# Altium schematic sheet MAIN.SchDoc(MAIN)
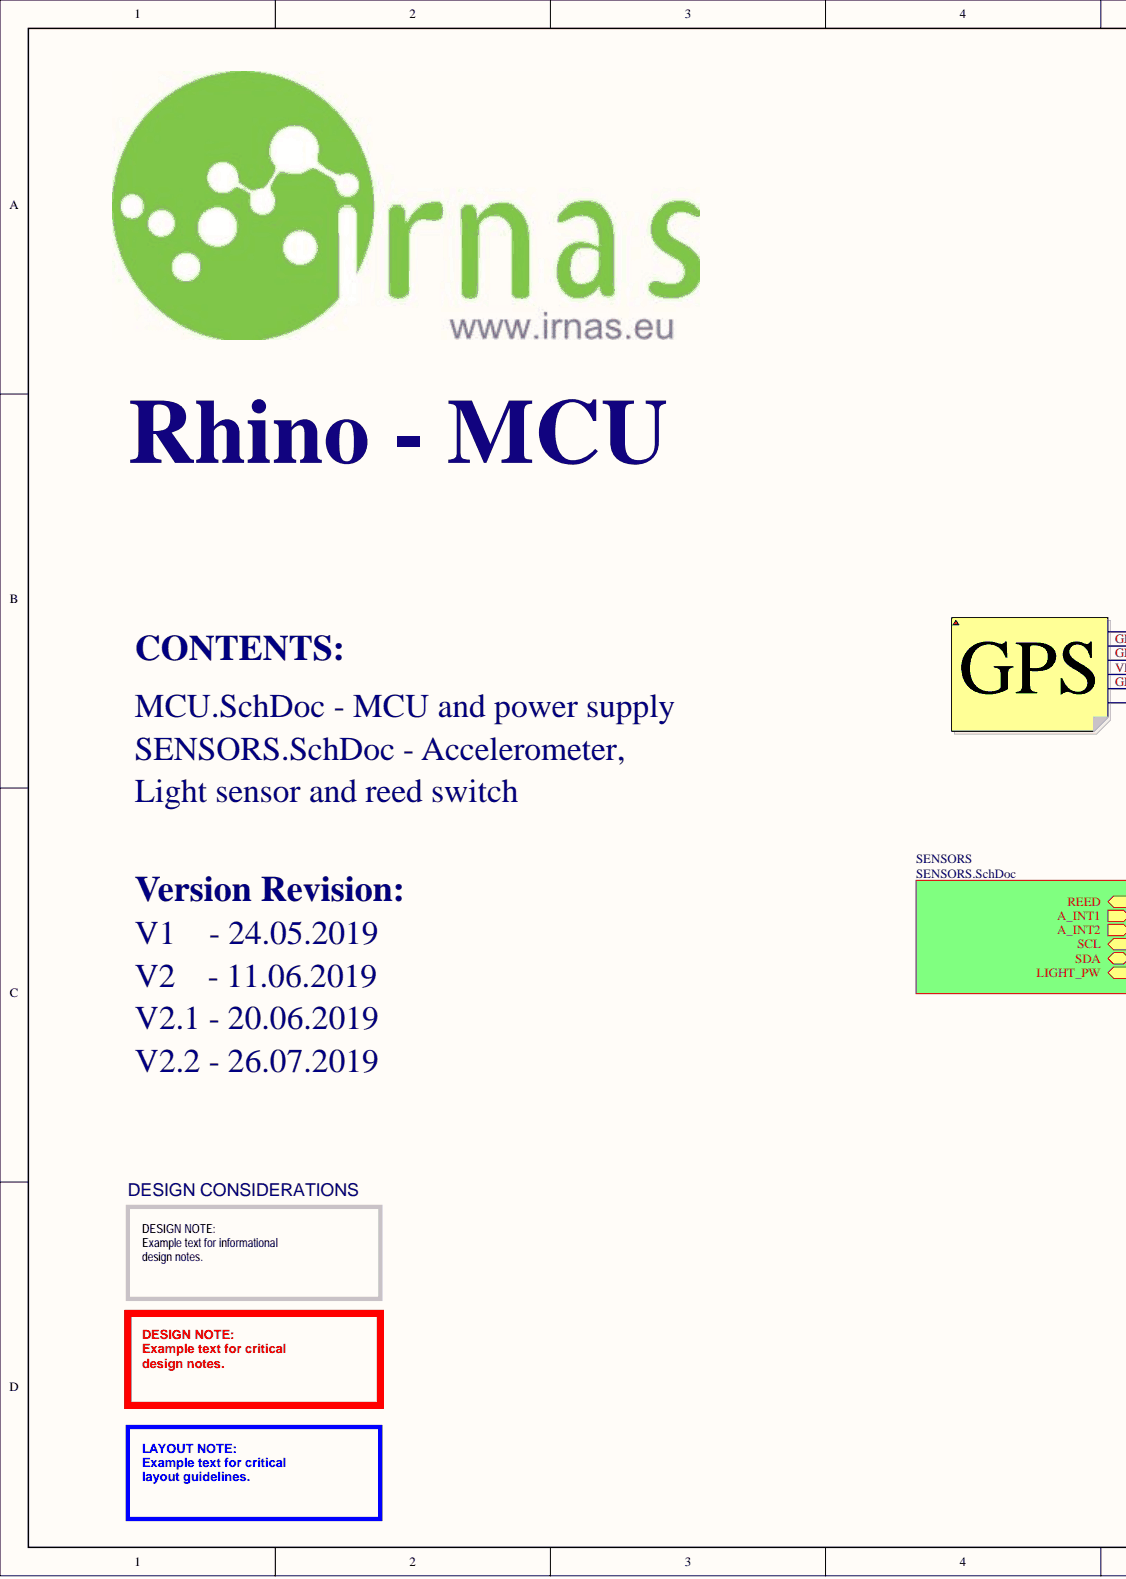
[diagram p0: MAIN.SchDoc, left]
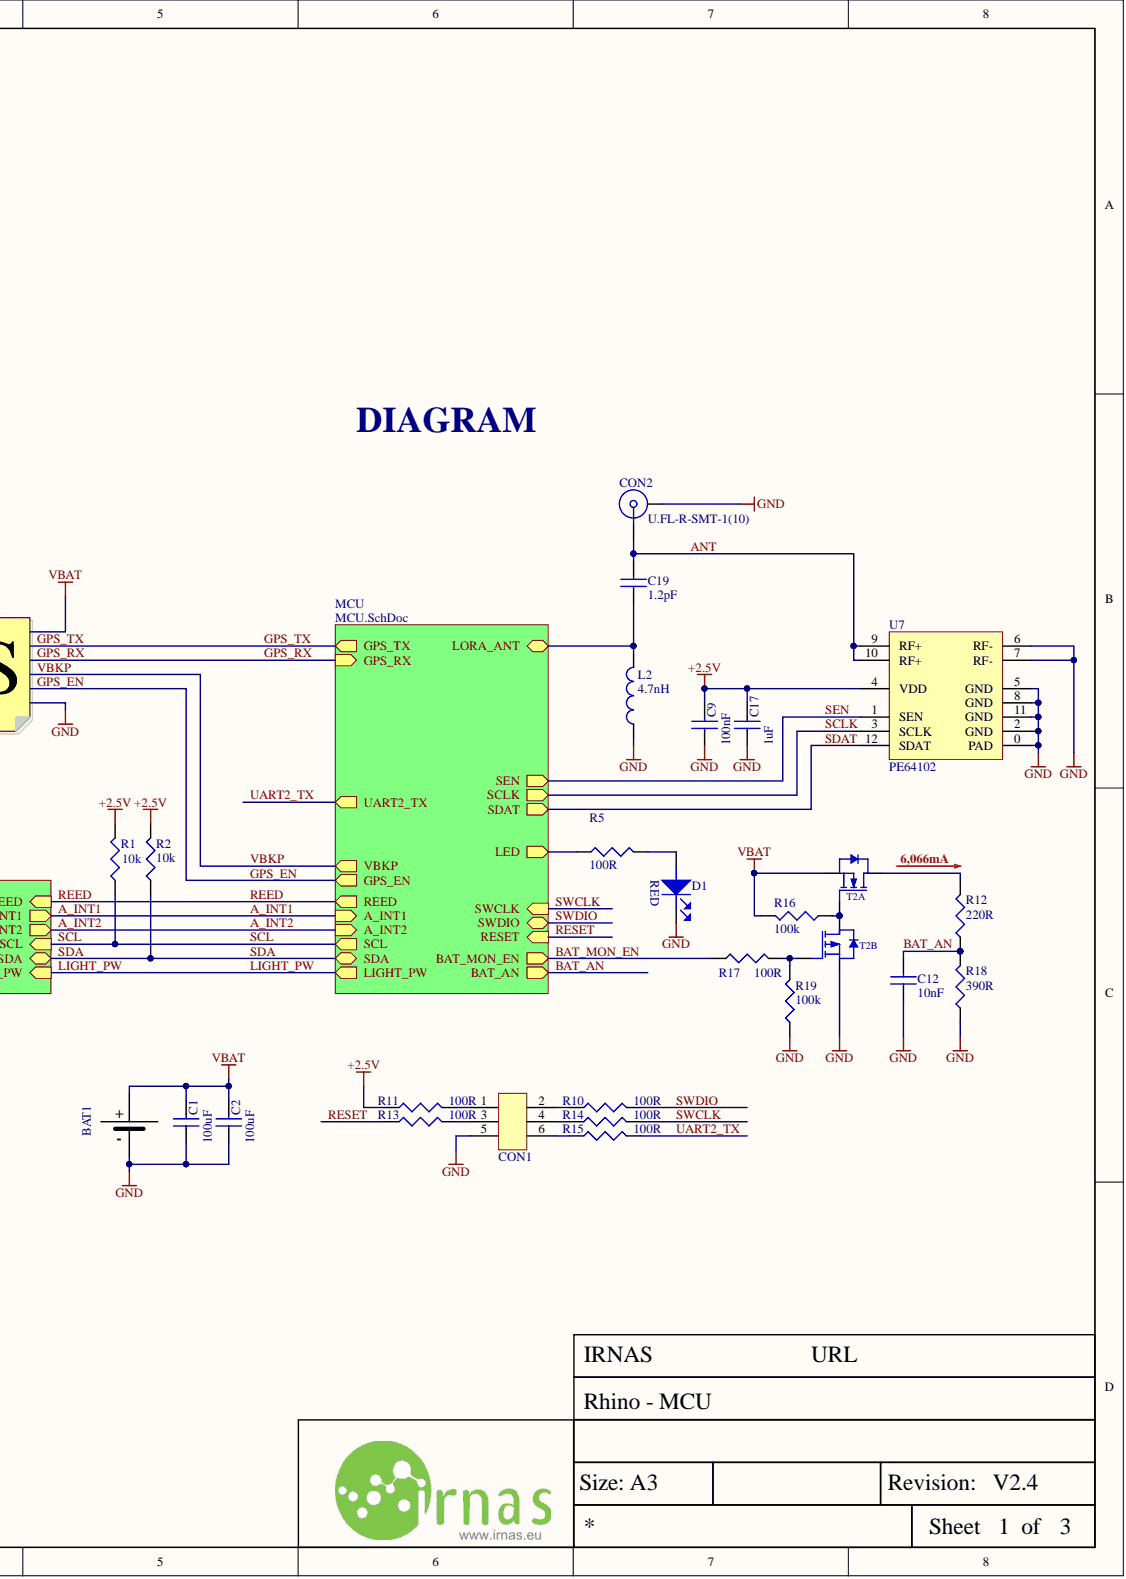
[diagram p1: MAIN.SchDoc, right]

## Parts
BAT1  SAFT LS 14250PFR  [SAFT LS 14250PFR]  (value not drawn)
C1  100uF  [CAP0805]
C2  100uF  [CAP0805]
C9  100nF  [CAP0402]
C12  10nF  [CAP0402]
C17  1uF  [CAP0402]
C19  1.2pF  [CAP0402]
CON1  Tag connect  [TC2030-IDC-NL]  (value not drawn)  (no BOM)
CON2  U.FL-R-SMT-1(10)  [ufl connector smd]
D1  LED RED  [LED0603]  (value not drawn)
L2  4.7nH  [IND0402]
R1  10k  [RES0402]
R2  10k  [RES0402]
R5  100R  [RES0402]
R10  100R  [RES0402]
R11  100R  [RES0402]
R12  220R  [RES0402]
R13  100R  [RES0402]
R14  100R  [RES0402]
R15  100R  [RES0402]
R16  100k  [RES0402]
R17  100R  [RES0402]
R18  390R  [RES0402]
R19  100k  [RES0402]
T2  VT6M1T2CR  [VMT6]  (value not drawn)  (parts A,B)
U7  PE64102  [QFN-12]

## Nets
+2.5V: C9.2 C17.2 R1.2 R2.2 R11.1 U7.4(VDD)
GND: BAT1.1 C1.1 C2.1 C9.1 C12.1 C17.1 CON1.5 CON2.2 D1.1 L2.1 R18.1 R19.1 T2.4 U7.0(PAD) U7.2(GND) U7.5(GND) U7.6(RF-) U7.7(RF-) U7.8(GND) U7.11(GND)
VBAT: BAT1.2 C1.2 C2.2 R16.2 T2.1
ANT: C19.2 CON2.1 U7.9(RF+) U7.10(RF+)
BAT_AN: C12.2 R12.1 R18.2
BAT_MON_EN: R17.1
RESET: R13.1
SCL: R1.1
SCLK: U7.3(SCLK)
SDA: R2.1
SDAT: U7.12(SDAT)
SEN: U7.1(SEN)
SWCLK: R14.2
SWDIO: R10.2
UART2_TX: R15.2
NetC19_1: C19.1 L2.2
NetCON1_1: CON1.1 R11.2
NetCON1_2: CON1.2 R10.1
NetCON1_3: CON1.3 R13.2
NetCON1_4: CON1.4 R14.1
NetCON1_6: CON1.6 R15.1
NetD1_2: D1.2 R5.2
NetR5_1: R5.1
NetR12_2: R12.2 T2.6
NetR16_1: R16.1 T2.2 T2.3
NetR17_2: R17.2 R19.2 T2.5
A_INT1:
A_INT2:
GPS_EN:
GPS_RX:
GPS_TX:
LIGHT_PW:
REED:
VBKP:

## Library data of the parts
BAT1 = BAT - SAFT LS 14250PFR: Battery: lithium; 3.6V; 1/2AA; 3pin,positive pole: 1pin; 1200mAh; Supplier Part Number 1: SAFT-LS14250PFR; Supplier 1: TME; Library Name: Mechanical.SchLib; Component Kind: Standard
C1 C2 = C - 100uF/0805: Multilayer Ceramic Capacitors MLCC - SMD/SMT 0805 100uF 4volts *Derate Voltage/Temp; Manufacturer Part Number: GRM21BR60G107ME15L; Manufacturer: Murata; IRNAS Part No.: /; Voltage Rating (DC): 4V; Tolerance: 20%; Dissipation Factor: 0.1%; Dielectric: X5R; Width: 0.049inch; Weight: 0.000335oz; Voltage Rating: 4V; Voltage: 4V; Thickness: 0.057inch; Termination: SMD/SMT; Supplier Part Number 1: 2611937; Supplier 1: Farnell; Stock 1: 529; REACH SVHC: No SVHC; Pricing 1: 5=0.775, 50=0.463, 250=0.405, 500=0.289, 1500=0.243, 3000=0.229, 15000=0.21 (GBP); Packaging: Tape and Reel; Package Quantity: 3000; Mount: Surface Mount; Min Operating Temperature: -55°C; Max Operating Temperature: 85°C; Library Name: Passive.SchLib; Length: 2mm; Lead Free: Lead Free; Height: 1.25mm; Dielectric Material: Ceramic; Depth: 1.25mm; ComponentLink4URL: http://www.mouser.com/ds/2/281/c02e-2905.pdf; ComponentLink4Description: http://www.mouser.com/ds/2/281/c02e-2905.pdf; ComponentLink3URL: http://psearch.en.murata.com/capacitor/product/GRM21BR60G107ME15%23.pdf; ComponentLink3Description: http://psearch.en.murata.com/capacitor/product/GRM21BR60G107ME15%23.pdf; ComponentLink2URL: http://search.murata.co.jp/Ceramy/image/img/A01X/partnumbering_e_01.pdf; ComponentLink2Description: http://search.murata.co.jp/Ceramy/image/img/A01X/partnumbering_e_01.pdf; ComponentLink1URL: http://www.farnell.com/datasheets/2079181.pdf; ComponentLink1Description: http://www.farnell.com/datasheets/2079181.pdf; Component Kind: Standard; Case Code (Metric): 2012; Case Code (Imperial): 0805; Case/Package: 0805; Capacitance: 100uF
C9 = C - 100nF/0402: MULTICOMP         MC0402X104J160CT             SMD Multilayer Ceramic Capacitor, 0402 [1005 Metric], 0.1 F, 16 V,  5%, X5R, MC Series                          New; IRNAS Part No.: /; Voltage Rating (DC): 16V; Voltage Rating: 16V; Tolerance: 5%; Supplier Part Number 1: 2627419; Supplier 1: Farnell; Stock 1: 3230; REACH SVHC: No SVHC; Pricing 1: 10=0.0139, 100=0.0108, 500=0.0076, 2500=0.0051, 5000=0.0038 (EUR); Packaging: Tape and Reel; Min Operating Temperature: -55°C; Max Operating Temperature: 85°C; Manufacturer Part Number: MC0402X104J160CT; Manufacturer: Multicomp; Library Name: Passive.SchLib; Dielectric: X5R; ComponentLink2URL: http://www.farnell.com/datasheets/2117900.pdf; ComponentLink2Description: http://www.farnell.com/datasheets/2117900.pdf; ComponentLink1URL: http://www.farnell.com/datasheets/2117933.pdf; ComponentLink1Description: http://www.farnell.com/datasheets/2117933.pdf; Component Kind: Standard; Case Code (Metric): 1005; Case Code (Imperial): 0402; Capacitance: 100nF
C12 = C - 10nF/0402: CAP CER 10000PF 16V X7R 0402; Manufacturer Part Number: GRM155R71C103KA01D; Manufacturer: Murata; IRNAS Part No.: /; Tolerance: 10%; Width: 0.02inch; Voltage Rating (DC): 16V; Voltage Rating: 16V; Voltage: 16V; Thickness: 0.022inch; Termination: SMD/SMT; Supplier Part Number 1: 1828864; Supplier 1: Farnell; Stock 1: 16478; REACH SVHC: No SVHC; Radiation Hardening: No; Pricing 1: 10=0.0216, 100=0.0151, 500=0.0104, 2500=0.0069, 5000=0.0056 (EUR); Packaging: Tape and Reel; Package Quantity: 1; Number of Pins: 2; Mount: Surface Mount; Min Operating Temperature: -55°C; Max Operating Temperature: 125°C; Library Name: Passive.SchLib; Length: 1mm; Lead Free: Lead Free; Height: 0.5mm; Dielectric: X7R; Depth: 0.5mm; ComponentLink6URL: https://datasheet.ciiva.com/7937/608985-7937614.pdf; ComponentLink6Description: https://datasheet.ciiva.com/7937/608985-7937614.pdf; ComponentLink5URL: https://datasheet.ciiva.com/22698/608985-22698415.pdf; ComponentLink5Description: https://datasheet.ciiva.com/22698/608985-22698415.pdf; ComponentLink4URL: https://datasheet.ciiva.com/18184/getdatasheetpartid-178863-18184649.pdf; ComponentLink4Description: https://datasheet.ciiva.com/18184/getdatasheetpartid-178863-18184649.pdf; ComponentLink3URL: https://datasheet.ciiva.com/129/product-129776.pdf; ComponentLink3Description: https://datasheet.ciiva.com/129/product-129776.pdf; ComponentLink2URL: https://datasheet.ciiva.com/129/partnumbering-e-01-129775.pdf; ComponentLink2Description: https://datasheet.ciiva.com/129/partnumbering-e-01-129775.pdf; ComponentLink1URL: https://datasheet.ciiva.com/129/partnumbering-e-01-129774.pdf; ComponentLink1Description: https://datasheet.ciiva.com/129/partnumbering-e-01-129774.pdf; Component Kind: Standard; Case Code (Metric): 1005; Case Code (Imperial): 0402; Case/Package: 0402; Capacitance: 10nF
C17 = C - 1uF/0402: CAP CER 1UF 10V 20% X5R 0402; Manufacturer Part Number: GRM155R61A105ME15D; Manufacturer: Murata; IRNAS Part No.: /; Width: 0.02inch; Voltage Rating (DC): 10V; Voltage Rating: 10V; Voltage: 10V; Tolerance: 20%; Thickness: 0.022inch; Supplier Part Number 1: 2426953; Supplier 1: Farnell; Stock 1: 0; REACH SVHC: No SVHC; Radiation Hardening: No; Pricing 1: 10=0.0379, 100=0.0266, 500=0.0156, 2500=0.0098, 5000=0.0083 (EUR); Packaging: Tape and Reel; Package Quantity: 1; Mount: Surface Mount; Min Operating Temperature: -55°C; Max Operating Temperature: 85°C; Library Name: Passive.SchLib; Length: 1mm; Lead Free: Lead Free; Height: 0.5mm; Dielectric: X5R; Depth: 0.5mm; ComponentLink5URL: https://datasheet.ciiva.com/164/partnumbering-e-01-164667.pdf; ComponentLink5Description: https://datasheet.ciiva.com/164/partnumbering-e-01-164667.pdf; ComponentLink4URL: https://datasheet.ciiva.com/164/partnumbering-e-01-164666.pdf; ComponentLink4Description: https://datasheet.ciiva.com/164/partnumbering-e-01-164666.pdf; ComponentLink3URL: https://datasheet.ciiva.com/46418/1769893-46418047.pdf; ComponentLink3Description: https://datasheet.ciiva.com/46418/1769893-46418047.pdf; ComponentLink2URL: https://datasheet.ciiva.com/11616/product-11616508.pdf; ComponentLink2Description: https://datasheet.ciiva.com/11616/product-11616508.pdf; ComponentLink1URL: https://datasheet.ciiva.com/164/product-164665.pdf; ComponentLink1Description: https://datasheet.ciiva.com/164/product-164665.pdf; Component Kind: Standard; Case Code (Metric): 1005; Case Code (Imperial): 0402; Case/Package: 0402; Capacitance: 1uF
C19 = C - 4.7nF/0402: CAP CER 4700PF 50V X7R 0402; Manufacturer Part Number: C0402C472K5RAC-TU; Manufacturer: KEMET; IRNAS Part No.: /; Tolerance: 10%; Dielectric Material: Ceramic; Capacitance: 4.7nF; Width: 0.02inch; Voltage Rating (DC): 50V; Voltage Rating: 50V; Voltage: 50V; Thickness: 0.022inch; Termination: SMD/SMT; Supplier Part Number 1: 1414594; Supplier 1: Farnell; Stock 1: 98953; REACH SVHC: No SVHC; Radiation Hardening: No; Pricing 1: 10=0.0321, 100=0.0144, 500=0.0081, 2500=0.0057, 5000=0.0048 (EUR); Packaging: Tape and Reel; Package Quantity: 1; Number of Pins: 2; Mount: Surface Mount,PCB; Min Operating Temperature: -55°C; Max Operating Temperature: 125°C; Library Name: Passive.SchLib; Length: 1mm; Lead Pitch: 0.3mm; Height: 0.5mm; Dielectric: X7R; Depth: 0.5mm; ComponentLink6URL: https://datasheet.ciiva.com/18102/getdatasheetpartid-63228-18102058.pdf; ComponentLink6Description: https://datasheet.ciiva.com/18102/getdatasheetpartid-63228-18102058.pdf; ComponentLink5URL: https://datasheet.ciiva.com/14602/70095383-14602057.pdf; ComponentLink5Description: https://datasheet.ciiva.com/14602/70095383-14602057.pdf; ComponentLink4URL: https://datasheet.ciiva.com/6074/kem-c1002-x7r-smd-6074645.pdf; ComponentLink4Description: https://datasheet.ciiva.com/6074/kem-c1002-x7r-smd-6074645.pdf; ComponentLink3URL: https://datasheet.ciiva.com/14604/70095383-14604382.pdf; ComponentLink3Description: https://datasheet.ciiva.com/14604/70095383-14604382.pdf; ComponentLink2URL: https://datasheet.ciiva.com/7747/40889-7747550.pdf; ComponentLink2Description: https://datasheet.ciiva.com/7747/40889-7747550.pdf; ComponentLink1URL: https://datasheet.ciiva.com/7747/40889-7747549.pdf; ComponentLink1Description: https://datasheet.ciiva.com/7747/40889-7747549.pdf; Component Kind: Standard; Case Code (Metric): 1005; Case Code (Imperial): 0402; Case/Package: 0402
CON1 = tag connecot 6 pin: Library Name: Connectors.SchLib
CON2 = U.FL-R-SMT-1(10): RF / Coaxial Connector, U.FL Coaxial, Straight Jack, Surface Mount Vertical, 50 ohm, Brass; Manufacturer: Hirose; Shield Termination: Solder; Other Names: H11891TR  Q5400621  U.FL-R-SMT-1 10  U.FL-R-SMT-1(10)-ND  UFLRSMT110; Online Catalog: UMCC (Ultra Miniature Coax Connectors), U.FL Series; Impedance: 50 Ohm; Frequency - Max: 6GHz; Featured Product: U.FL Series Connectors; Dielectric Material: Liquid Crystal Polymer (LCP); Connector Type: Receptacle, Male Pin; Connector Style: U.FL, Ultra Miniature Coaxial; Center Contact Plating: Gold; Body Material: Phosphor Bronze; Body Finish: Silver; Voltage Rating: -; Supplier Part Number 1: 1688077; Supplier 1: Farnell; Stock 1: 0; Standard Package: 2,500; Series: U.FL; Packaging: Tape & Reel (TR); Operating Temperature: -40°C ~ 90°C; Mounting Type: Surface Mount; Manufacturer Part Number: U.FL-R-SMT-1(10); Library Name: Connectors.SchLib; Ingress Protection: -; Housing Color: Beige; Features: -; Fastening Type: Snap-On; Family: Coaxial Connectors (RF); Contact Termination: Solder; ComponentLink3URL: http://www.farnell.com/datasheets/1947917.pdf; ComponentLink3Description: http://www.farnell.com/datasheets/1947917.pdf; ComponentLink2URL: http://uk.rs-online.com/webdocs/1363/0900766b81363563.pdf; ComponentLink2Description: http://uk.rs-online.com/webdocs/1363/0900766b81363563.pdf; ComponentLink1URL: http://www.farnell.com/datasheets/91524.pdf; ComponentLink1Description: http://www.farnell.com/datasheets/91524.pdf; Component Kind: Standard; Center Contact Material: Brass; Category: Connectors, Interconnects; Cable Group: -
D1 = LED - LED RED: BROADCOM LIMITED - HSMS-C190 - LED, RED, 10MCD, 626NM; Wavelength Typ: 626; Viewing Angle: 170°; SVHC: No SVHC (17-Dec-2015)<!--FANDB--><div class="features-and-benefits-container"><div class="features-and-benefits-description"><span class="features-benefits-value">The HSMS-C190 is a HER SMD Chip LED with untinted/diffused lens, made of GaP. It is designed in an industry standard package 1.6 x 0.8mm footprint with 0.8mm profile. It is compatible with IR reflow solder processes. The small size and wide viewing angle make this LED prime choices for backlighting applications and front panel illumination especially where space is a premium.</span></div><div class="features-and-benefits-list"><ul class="features-and-benefits-list-list"><li class="features-and-benefits-list-item">Small size</li><li class="features-and-benefits-list-item">Industry standard footprint</li><li class="features-and-benefits-list-item">Compatible with IR solder</li><li class="features-and-benefits-list-item">Diffused optics</li><li class="features-and-benefits-list-item">-40 to 85; Luminous Intensity: 10; Lens Shape: Rectangular; LED Mounting: SMD; Forward Voltage: 2.1; Forward Current If: 20; Supplier Part Number 1: 2497356; Supplier 1: Farnell; Stock 1: 7534; RoHS: YES; Product Range: -; Pricing 1: 1=0.361, 10=0.256, 50=0.235, 100=0.106, 200=0.101 (GBP); Packaging: Cut Tape; MSL: -; Manufacturer Part Number: HSMS-C190; Manufacturer: BROADCOM LIMITED; Library Name: Active.SchLib; LED Colour: Red; ComponentLink3URL: http://www.farnell.com/datasheets/2165483.pdf; ComponentLink3Description: http://www.farnell.com/datasheets/2165483.pdf; ComponentLink2URL: http://www.farnell.com/datasheets/1681524.pdf; ComponentLink2Description: http://www.farnell.com/datasheets/1681524.pdf; ComponentLink1URL: http://www.farnell.com/datasheets/313031.pdf; ComponentLink1Description: http://www.farnell.com/datasheets/313031.pdf; Component Kind: Standard; Bulb Size: -; Automotive Qualification Standard: -
L2 = L - 4.3nH/0402: Inductor RF Chip Multi-Layer 4.3nH 0.3nH 100MHz 8Q-Factor Air 300mA 210mOhm DCR 0402 Paper T/R; Manufacturer Part Number: LQG15HN4N3S02D; Manufacturer: Murata; IRNAS Part No.: /; Shielding: Unshielded; Self Resonant Frequency: 6GHz; Q Factor: 8; DC Resistance (DCR): 210mR; Width: 0.02inch; Test Frequency: 100MHz; Termination: SMD/SMT; Supplier Part Number 1: 1711735; Supplier 1: Farnell; Stock 1: 3502; RoHS: Yes; REACH SVHC: No SVHC; Radiation Hardening: No; Pricing 1: 1 = 0.10000, 10 = 0.06100, 100 = 0.04040, 500 = 0.03366, 1000 = 0.02827, 2500 = 0.02423 (USD); Packaging: Tape and Reel; Package Quantity: 10000; Mount: Surface Mount; Min Operating Temperature: -55°C; Military Standard: Not; Max Operating Temperature: 125°C; Max DC Current: 300mA; Library Name: Passive.SchLib; Length: 1.05mm; Lead Free: Lead Free; Inductance: 4.3nh; Height - Seated (Max): 0.022inch; Height: 0.5mm; Depth: 0.55mm; DC Current: 300mA; Current Rating: 300mA; Current: 300mA; Core Material: Air; ComponentLink6URL: https://search.murata.co.jp/Ceramy/image/img/P02/partnumberingAT_LQ3E.pdf?src-supplier=Digi-Key; ComponentLink6Description: https://search.murata.co.jp/Ceramy/image/img/P02/partnumberingAT_LQ3E.pdf?src-supplier=Digi-Key; ComponentLink5URL: http://search.murata.co.jp/Ceramy/image/img/PDF/ENG/L0075S0083LQG15HN.pdf?src-supplier=Avnet+Europe; ComponentLink5Description: http://search.murata.co.jp/Ceramy/image/img/PDF/ENG/L0075S0083LQG15HN.pdf?src-supplier=Avnet+Europe; ComponentLink4URL: https://www.verical.com/server-webapp/html/getDatasheet?partID=31252&src-supplier=Verical; ComponentLink4Description: https://www.verical.com/server-webapp/html/getDatasheet?partID=31252&src-supplier=Verical; ComponentLink3URL: http://www.farnell.com/datasheets/66026.pdf?src-supplier=Element14; ComponentLink3Description: http://www.farnell.com/datasheets/66026.pdf?src-supplier=Element14; ComponentLink2URL: http://search.murata.co.jp/Ceramy/image/img/PDF/ENG/L0075S0083LQG15HN.pdf?src-supplier=Avnet; ComponentLink2Description: http://search.murata.co.jp/Ceramy/image/img/PDF/ENG/L0075S0083LQG15HN.pdf?src-supplier=Avnet; ComponentLink1URL: http://uk.rs-online.com/webdocs/0f10/0900766b80f10808.pdf?src-supplier=RSComponents; ComponentLink1Description: http://uk.rs-online.com/webdocs/0f10/0900766b80f10808.pdf?src-supplier=RSComponents; Component Kind: Standard; Case Code (Metric): 1005; Case Code (Imperial): 0402; Case/Package: 0402
R1 R2 = R - 10k/0402: MULTICOMP         MCWR04X1002FTL             SMD Chip Resistor, Thick Film, 10 kohm, 50 V, 0402 [1005 Metric], 62.5 mW,  1%, MCWR Series; IRNAS Part No.: I-100014; Voltage Rating (DC): 50V; Temperature Coefficient: 100ppm/°C; Resistance: 10kR; Power Rating: 62.5mW; Voltage Rating: 50V; Tolerance: 1%; Supplier Part Number 1: 2447096; Supplier 1: Farnell; Stock 1: 602609; REACH SVHC: No SVHC; Pricing 1: 10=0.0029, 100=0.0017, 500=0.0012, 2500=0.001, 5000=0.0008 (EUR); Packaging: Cut Tape; Manufacturer Part Number: MCWR04X1002FTL; Manufacturer: Multicomp; Library Name: Passive.SchLib; Composition: Thick Film; ComponentLink1URL: http://www.farnell.com/datasheets/1849611.pdf; ComponentLink1Description: http://www.farnell.com/datasheets/1849611.pdf; Component Kind: Standard; Case Code (Metric): 1005; Case Code (Imperial): 0402; Case/Package: 0402
R5 R10 R11 R13-R15 R17 = R - 100R/0402: MULTICOMP         MCMR04X1000FTL            SMD Chip Resistor, Ceramic, MCMR Series, 100 ohm, 50 V, 0402 [1005 Metric], 62.5 mW,  1%; IRNAS Part No.: /; Voltage Rating (DC): 50V; Temperature Coefficient: 100ppm/°C; Power Rating: 62.5mW; Voltage Rating: 50V; Tolerance: 1%; Supplier Part Number 1: 2072515; Supplier 1: Farnell; Stock 1: 62365; Resistance: 100R; REACH SVHC: No SVHC; Pricing 1: 10=0.0071, 100=0.0042, 500=0.003, 2500=0.0024, 5000=0.0019 (GBP); Packaging: Cut Tape; Manufacturer Part Number: MCMR04X1000FTL; Manufacturer: Multicomp; Library Name: Passive.SchLib; Composition: Ceramic; ComponentLink2URL: https://datasheet.ciiva.com/22708/1716705-22708156.pdf; ComponentLink2Description: https://datasheet.ciiva.com/22708/1716705-22708156.pdf; ComponentLink1URL: https://datasheet.ciiva.com/28692/1716705-28692087.pdf; ComponentLink1Description: https://datasheet.ciiva.com/28692/1716705-28692087.pdf; Component Kind: Standard; Case Code (Metric): 1005; Case Code (Imperial): 0402; Case/Package: 0402
R12 = R - 220R/0402: SMD Chip Resistor, 0402 [1005 Metric], 220 ohm, CRCW e3 Series, 50 V, Thick Film, 63 mW; Manufacturer: Multicomp; IRNAS Part No.: /; Voltage Rating (DC): 50V; Temperature Coefficient: 400ppm/°C; Power Rating: 62.5mW; Voltage Rating: 50V; Supplier Part Number 1: 2140704; Supplier 1: Farnell; Stock 1: 0; Resistance: 220R; REACH SVHC: No SVHC; Pricing 1: 10=0.0057, 100=0.0034, 500=0.0024, 2500=0.0019, 5000=0.0015 (EUR); Packaging: Cut Tape; Library Name: Passive.SchLib; Composition: Ceramic; ComponentLink1URL: http://www.farnell.com/datasheets/2310790.pdf?_ga=2.80708247.1822379758.1564985606-1373726713.1554121096&_gac=1.157944520.1562763802.CjwKCAjwmZbpBRAGEiwADrmVXm8xT8OHDZvJe8qZIQyyGmIuiUD-TEITatw0hIdDkyBwIXXCH7oneRoCl7cQAvD_BwE; ComponentLink1Description: Datasheet; Component Kind: Standard; Case Code (Metric): 1005; Case Code (Imperial): 0402; Case/Package: 0402
R16 R19 = R - 100k/0402: MULTICOMP   MCWR04X1003FTL   RES, THICK FILM, 100KOHM, 1%, 0.0625W; IRNAS Part No.: I-100013; Voltage Rating (DC): 50V; Temperature Coefficient: 100ppm/°C; Resistance: 100kR; Power Rating: 62.5mW; Voltage Rating: 50V; Tolerance: 1%; Supplier Part Number 1: 2447094; Supplier 1: Farnell; Stock 1: 161188; REACH SVHC: No SVHC; Pricing 1: 10=0.0029, 100=0.0017, 500=0.0012, 2500=0.001, 5000=0.0008 (EUR); Packaging: Cut Tape; Mount: Surface Mount; Manufacturer Part Number: MCWR04X1003FTL; Manufacturer: Multicomp; Library Name: Passive.SchLib; Composition: Thick Film; ComponentLink1URL: https://datasheet.ciiva.com/46386/1849611-46386524.pdf; ComponentLink1Description: https://datasheet.ciiva.com/46386/1849611-46386524.pdf; Component Kind: Standard; Case Code (Metric): 1005; Case Code (Imperial): 0402; Case/Package: 0402
R18 = R - 390R/0402: SMD Chip Resistor, 0402 [1005 Metric], 390 ohm, MCWR Series, 50 V, Thick Film, 62.5 mW; Manufacturer: Multicomp; IRNAS Part No.: /; Voltage Rating (DC): 50V; Temperature Coefficient: 400ppm/°C; Power Rating: 62.5mW; Voltage Rating: 50V; Supplier Part Number 1: 2447165; Supplier 1: Farnell; Stock 1: 0; Resistance: 390R; REACH SVHC: No SVHC; Pricing 1: 10=0.0057, 100=0.0034, 500=0.0024, 2500=0.0019, 5000=0.0015 (EUR); Packaging: Cut Tape; Library Name: Passive.SchLib; Composition: Ceramic; ComponentLink1URL: http://www.farnell.com/datasheets/2563624.pdf?_ga=2.57959210.1822379758.1564985606-1373726713.1554121096&_gac=1.48955732.1562763802.CjwKCAjwmZbpBRAGEiwADrmVXm8xT8OHDZvJe8qZIQyyGmIuiUD-TEITatw0hIdDkyBwIXXCH7oneRoCl7cQAvD_BwE; ComponentLink1Description: Datasheet; Component Kind: Standard; Case Code (Metric): 1005; Case Code (Imperial): 0402; Case/Package: 0402
T2 = T_M - VT6M1T2CR: Dual MOSFET, N and P Channel, 100 mA, 20 V, 2.5 ohm, 4.5 V, 1 V; Velikost / Size: SOT23; Value: BSS123; Opis / Description: MOSFET BSS123       /N-Channel; Komp / Device: SMD; FIT: 0.44; ELRAD Id sifra / ELRAD Id No: 597778; Supplier Part Number 1: 2706721; Supplier 1: Farnell; Library Name: Active.SchLib; Date: 11.07.2018; ComponentLINK2URL: http://www.onsemi.com/pub/Collateral/BSS123-D.PDF; ComponentLINK2Description: Datasheet www; ComponentLink1URL: http://www.farnell.com/datasheets/2198041.pdf?_ga=2.191543850.1451481302.1563774341-1373726713.1554121096&_gac=1.95045230.1562763802.CjwKCAjwmZbpBRAGEiwADrmVXm8xT8OHDZvJe8qZIQyyGmIuiUD-TEITatw0hIdDkyBwIXXCH7oneRoCl7cQAvD_BwE; ComponentLINK1Description: Datasheet; ComponentLink1Description: Datasheet; Component Kind: Standard; ComponentLINK1URL: c:\ELRADSVN\Altium_Library\Libraries\Active\Datasheet\BSS123.pdf; Author: Ciril Golob
U7 = RF - PE64102: RF IC Digitally Tunable Capacitor General Purpose 100MHz ~ 3GHz SPI Interface 12-QFN (2x2); Manufacturer Part Number: MAX-M8Q-0; Manufacturer: u-blox; Supplier Part Number 1: 1046-1066-1-ND; Supplier 1: Digi-Key; Stock 1: 10805; Library Name: Active.SchLib; Component Kind: Standard
